# Altium schematic sheet LOGIC_FPGA.SchDoc
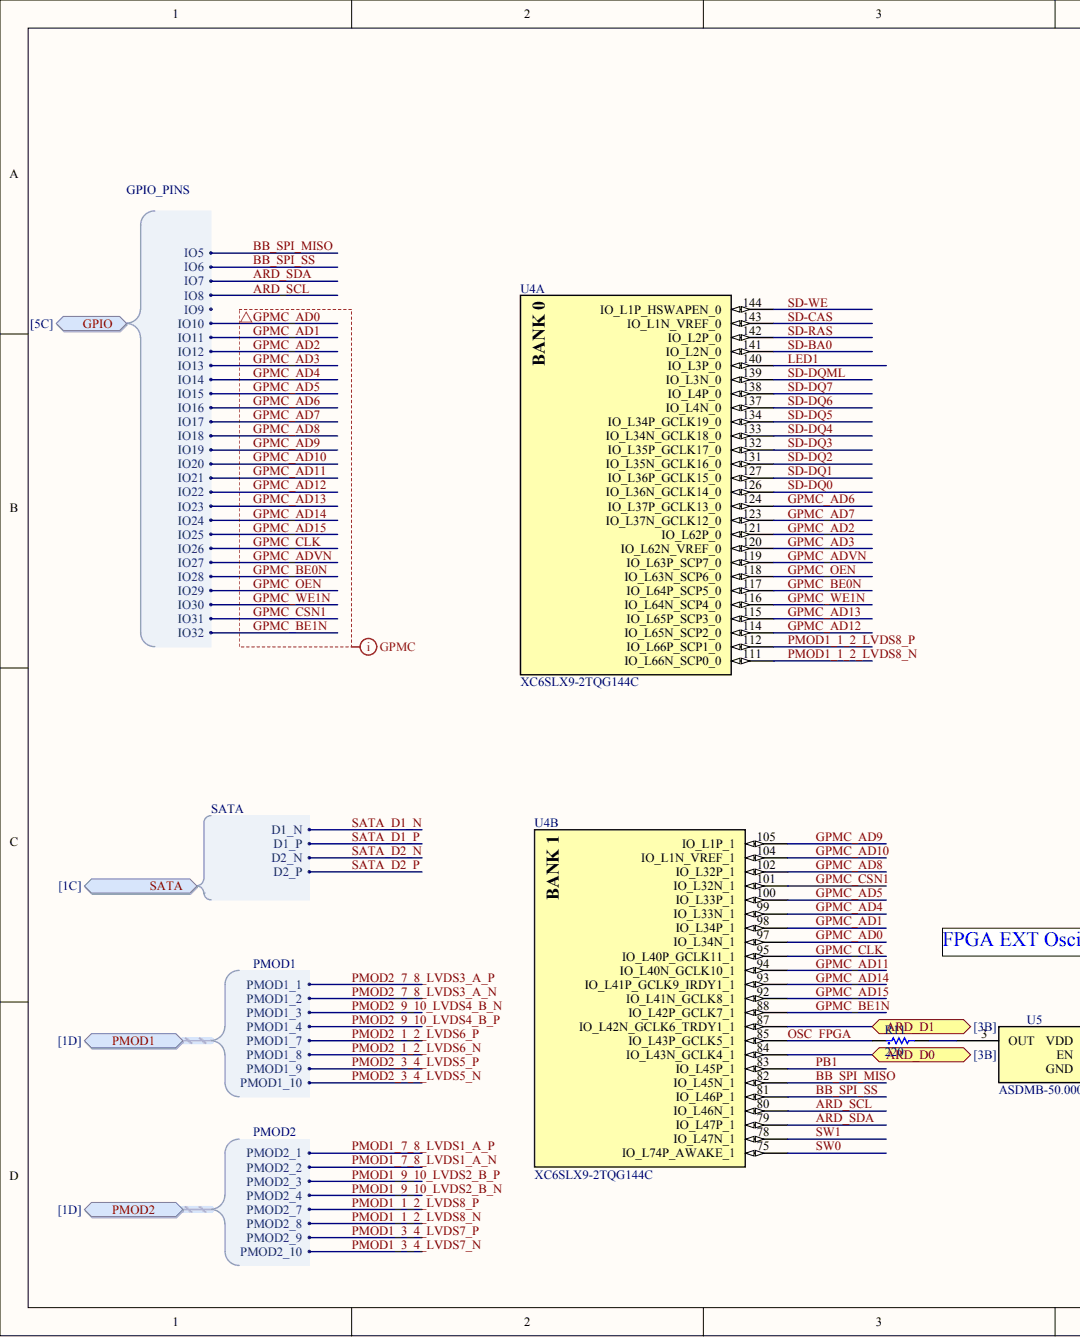
[diagram p0: LOGIC_FPGA.SchDoc, left]
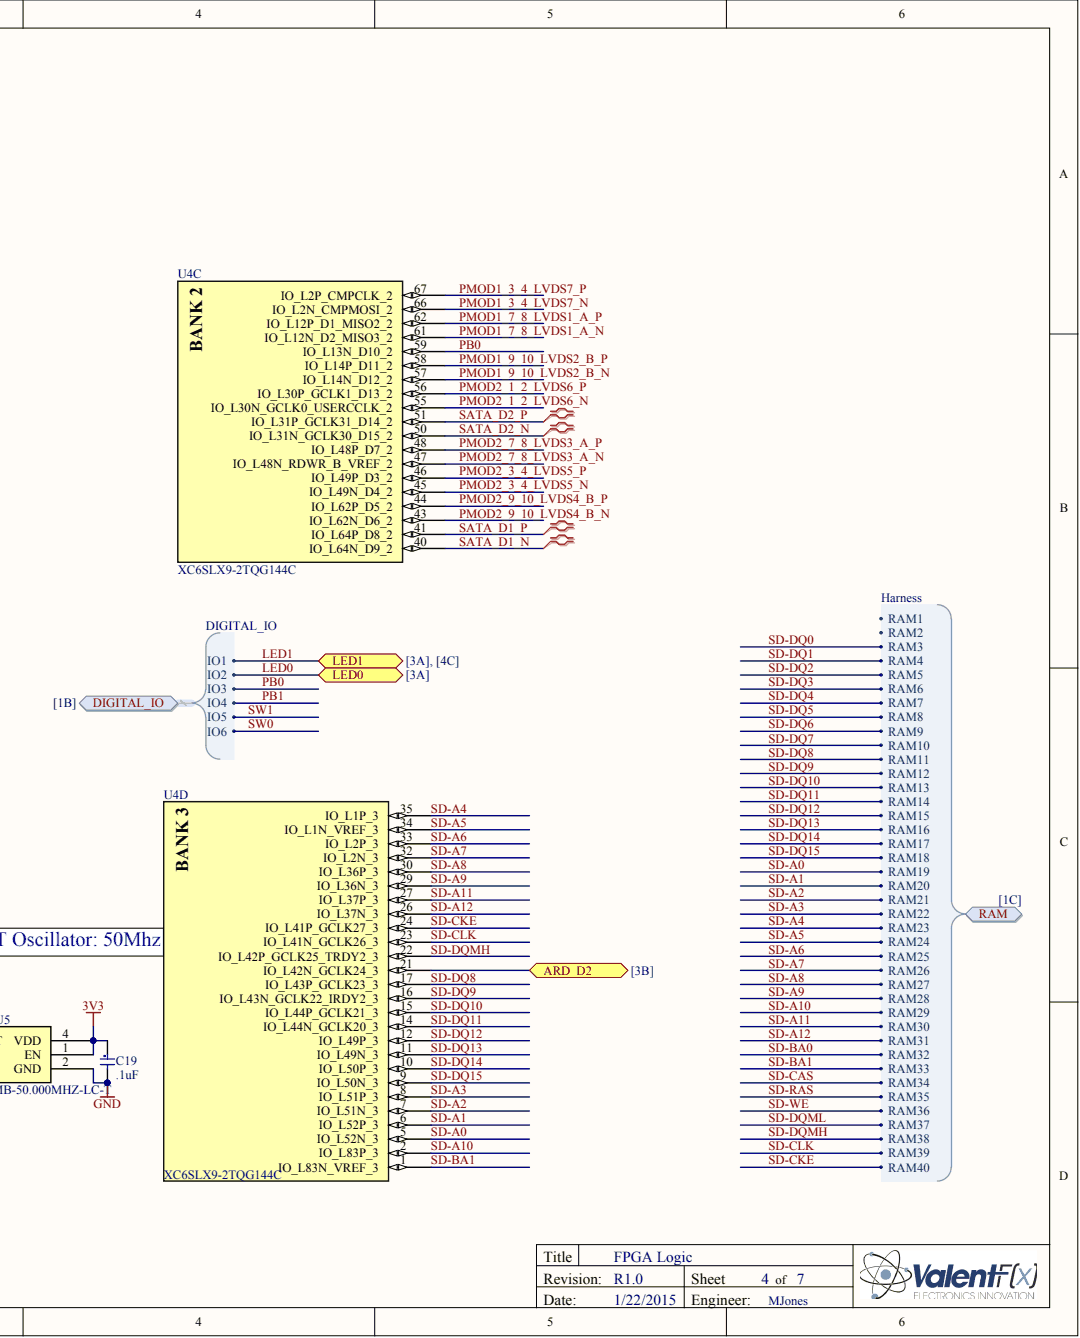
[diagram p1: LOGIC_FPGA.SchDoc, right]

## Parts
C19  .1uF  [c0603]
R11  220  [R0603]
U4  XC6SLX9-2TQG144C  [TQ144_L]  (parts A,B,C,D)
U5  ASDMB-50.000MHZ-LC-T  [ASDMB - osc]

## Ports
ARD_D0, ARD_D1, ARD_D2, DIGITAL_IO, DIGITAL_IO.IO1, DIGITAL_IO.IO2, DIGITAL_IO.IO3, DIGITAL_IO.IO4, DIGITAL_IO.IO5, DIGITAL_IO.IO6, GPIO, GPIO.IO5, GPIO.IO6, GPIO.IO7, GPIO.IO8, GPIO.IO9, GPIO.IO10, GPIO.IO11, GPIO.IO12, GPIO.IO13, GPIO.IO14, GPIO.IO15, GPIO.IO16, GPIO.IO17, GPIO.IO18, GPIO.IO19, GPIO.IO20, GPIO.IO21, GPIO.IO22, GPIO.IO23, GPIO.IO24, GPIO.IO25, GPIO.IO26, GPIO.IO27, GPIO.IO28, GPIO.IO29, GPIO.IO30, GPIO.IO31, GPIO.IO32, LED0, LED1, PMOD1, PMOD1.PMOD1_1, PMOD1.PMOD1_2, PMOD1.PMOD1_3, PMOD1.PMOD1_4, PMOD1.PMOD1_7, PMOD1.PMOD1_8, PMOD1.PMOD1_9, PMOD1.PMOD1_10, PMOD2, PMOD2.PMOD2_1, PMOD2.PMOD2_2, PMOD2.PMOD2_3, PMOD2.PMOD2_4, PMOD2.PMOD2_7, PMOD2.PMOD2_8, PMOD2.PMOD2_9, PMOD2.PMOD2_10, RAM, RAM.RAM1, RAM.RAM2, RAM.RAM3, RAM.RAM4, RAM.RAM5, RAM.RAM6, RAM.RAM7, RAM.RAM8, RAM.RAM9, RAM.RAM10, RAM.RAM11, RAM.RAM12, RAM.RAM13, RAM.RAM14, RAM.RAM15, RAM.RAM16, RAM.RAM17, RAM.RAM18, RAM.RAM19, RAM.RAM20, RAM.RAM21, RAM.RAM22, RAM.RAM23, RAM.RAM24, RAM.RAM25, RAM.RAM26, RAM.RAM27, RAM.RAM28, RAM.RAM29, RAM.RAM30, RAM.RAM31, RAM.RAM32, RAM.RAM33, RAM.RAM34, RAM.RAM35, RAM.RAM36, RAM.RAM37, RAM.RAM38, RAM.RAM39, RAM.RAM40, SATA, SATA.D1_N, SATA.D1_P, SATA.D2_N, SATA.D2_P

## Nets
3V3: C19.1 U5.1(EN) U5.4(VDD)
GND: C19.2 U5.2(GND)
ARD_D0: U4.84(IO_L43N_GCLK4_1) ; port ARD_D0
ARD_D1: U4.87(IO_L42N_GCLK6_TRDY1_1) ; port ARD_D1
ARD_D2: U4.21(IO_L42N_GCLK24_3) ; port ARD_D2
ARD_SCL: U4.80(IO_L46N_1) ; port GPIO
ARD_SDA: U4.79(IO_L47P_1) ; port GPIO
BB_SPI_MISO: U4.82(IO_L45N_1) ; port GPIO
BB_SPI_SS: U4.81(IO_L46P_1) ; port GPIO
GPMC_AD0: U4.97(IO_L34N_1) ; port GPIO
GPMC_AD1: U4.98(IO_L34P_1) ; port GPIO
GPMC_AD2: U4.121(IO_L62P_0) ; port GPIO
GPMC_AD3: U4.120(IO_L62N_VREF_0) ; port GPIO
GPMC_AD4: U4.99(IO_L33N_1) ; port GPIO
GPMC_AD5: U4.100(IO_L33P_1) ; port GPIO
GPMC_AD6: U4.124(IO_L37P_GCLK13_0) ; port GPIO
GPMC_AD7: U4.123(IO_L37N_GCLK12_0) ; port GPIO
GPMC_AD8: U4.102(IO_L32P_1) ; port GPIO
GPMC_AD9: U4.105(IO_L1P_1) ; port GPIO
GPMC_AD10: U4.104(IO_L1N_VREF_1) ; port GPIO
GPMC_AD11: U4.94(IO_L40N_GCLK10_1) ; port GPIO
GPMC_AD12: U4.114(IO_L65N_SCP2_0) ; port GPIO
GPMC_AD13: U4.115(IO_L65P_SCP3_0) ; port GPIO
GPMC_AD14: U4.93(IO_L41P_GCLK9_IRDY1_1) ; port GPIO
GPMC_AD15: U4.92(IO_L41N_GCLK8_1) ; port GPIO
GPMC_ADVN: U4.119(IO_L63P_SCP7_0) ; port GPIO
GPMC_BE0N: U4.117(IO_L64P_SCP5_0) ; port GPIO
GPMC_BE1N: U4.88(IO_L42P_GCLK7_1) ; port GPIO
GPMC_CLK: U4.95(IO_L40P_GCLK11_1) ; port GPIO
GPMC_CSN1: U4.101(IO_L32N_1) ; port GPIO
GPMC_OEN: U4.118(IO_L63N_SCP6_0) ; port GPIO
GPMC_WE1N: U4.116(IO_L64N_SCP4_0) ; port GPIO
LED1: U4.140(IO_L3P_0) ; port DIGITAL_IO, port LED1
OSC_FPGA: R11.1 U4.85(IO_L43P_GCLK5_1)
PB0: U4.59(IO_L13N_D10_2) ; port DIGITAL_IO
PB1: U4.83(IO_L45P_1) ; port DIGITAL_IO
PMOD1_1_2_LVDS8_N: U4.111(IO_L66N_SCP0_0) ; port PMOD2
PMOD1_1_2_LVDS8_P: U4.112(IO_L66P_SCP1_0) ; port PMOD2
PMOD1_3_4_LVDS7_N: U4.66(IO_L2N_CMPMOSI_2) ; port PMOD2
PMOD1_3_4_LVDS7_P: U4.67(IO_L2P_CMPCLK_2) ; port PMOD2
PMOD1_7_8_LVDS1_A_N: U4.61(IO_L12N_D2_MISO3_2) ; port PMOD2
PMOD1_7_8_LVDS1_A_P: U4.62(IO_L12P_D1_MISO2_2) ; port PMOD2
PMOD1_9_10_LVDS2_B_N: U4.57(IO_L14N_D12_2) ; port PMOD2
PMOD1_9_10_LVDS2_B_P: U4.58(IO_L14P_D11_2) ; port PMOD2
PMOD2_1_2_LVDS6_N: U4.55(IO_L30N_GCLK0_USERCCLK_2) ; port PMOD1
PMOD2_1_2_LVDS6_P: U4.56(IO_L30P_GCLK1_D13_2) ; port PMOD1
PMOD2_3_4_LVDS5_N: U4.45(IO_L49N_D4_2) ; port PMOD1
PMOD2_3_4_LVDS5_P: U4.46(IO_L49P_D3_2) ; port PMOD1
PMOD2_7_8_LVDS3_A_N: U4.47(IO_L48N_RDWR_B_VREF_2) ; port PMOD1
PMOD2_7_8_LVDS3_A_P: U4.48(IO_L48P_D7_2) ; port PMOD1
PMOD2_9_10_LVDS4_B_N: U4.43(IO_L62N_D6_2) ; port PMOD1
PMOD2_9_10_LVDS4_B_P: U4.44(IO_L62P_D5_2) ; port PMOD1
SATA_D1_N: U4.40(IO_L64N_D9_2) ; port SATA
SATA_D1_P: U4.41(IO_L64P_D8_2) ; port SATA
SATA_D2_N: U4.50(IO_L31N_GCLK30_D15_2) ; port SATA
SATA_D2_P: U4.51(IO_L31P_GCLK31_D14_2) ; port SATA
SD-A0: U4.5(IO_L52N_3) ; port RAM
SD-A1: U4.6(IO_L52P_3) ; port RAM
SD-A2: U4.7(IO_L51N_3) ; port RAM
SD-A3: U4.8(IO_L51P_3) ; port RAM
SD-A4: U4.35(IO_L1P_3) ; port RAM
SD-A5: U4.34(IO_L1N_VREF_3) ; port RAM
SD-A6: U4.33(IO_L2P_3) ; port RAM
SD-A7: U4.32(IO_L2N_3) ; port RAM
SD-A8: U4.30(IO_L36P_3) ; port RAM
SD-A9: U4.29(IO_L36N_3) ; port RAM
SD-A10: U4.2(IO_L83P_3) ; port RAM
SD-A11: U4.27(IO_L37P_3) ; port RAM
SD-A12: U4.26(IO_L37N_3) ; port RAM
SD-BA0: U4.141(IO_L2N_0) ; port RAM
SD-BA1: U4.1(IO_L83N_VREF_3) ; port RAM
SD-CAS: U4.143(IO_L1N_VREF_0) ; port RAM
SD-CKE: U4.24(IO_L41P_GCLK27_3) ; port RAM
SD-CLK: U4.23(IO_L41N_GCLK26_3) ; port RAM
SD-DQ0: U4.126(IO_L36N_GCLK14_0) ; port RAM
SD-DQ1: U4.127(IO_L36P_GCLK15_0) ; port RAM
SD-DQ2: U4.131(IO_L35N_GCLK16_0) ; port RAM
SD-DQ3: U4.132(IO_L35P_GCLK17_0) ; port RAM
SD-DQ4: U4.133(IO_L34N_GCLK18_0) ; port RAM
SD-DQ5: U4.134(IO_L34P_GCLK19_0) ; port RAM
SD-DQ6: U4.137(IO_L4N_0) ; port RAM
SD-DQ7: U4.138(IO_L4P_0) ; port RAM
SD-DQ8: U4.17(IO_L43P_GCLK23_3) ; port RAM
SD-DQ9: U4.16(IO_L43N_GCLK22_IRDY2_3) ; port RAM
SD-DQ10: U4.15(IO_L44P_GCLK21_3) ; port RAM
SD-DQ11: U4.14(IO_L44N_GCLK20_3) ; port RAM
SD-DQ12: U4.12(IO_L49P_3) ; port RAM
SD-DQ13: U4.11(IO_L49N_3) ; port RAM
SD-DQ14: U4.10(IO_L50P_3) ; port RAM
SD-DQ15: U4.9(IO_L50N_3) ; port RAM
SD-DQMH: U4.22(IO_L42P_GCLK25_TRDY2_3) ; port RAM
SD-DQML: U4.139(IO_L3N_0) ; port RAM
SD-RAS: U4.142(IO_L2P_0) ; port RAM
SD-WE: U4.144(IO_L1P_HSWAPEN_0) ; port RAM
SW0: U4.75(IO_L74P_AWAKE_1) ; port DIGITAL_IO
SW1: U4.78(IO_L47N_1) ; port DIGITAL_IO
NetR11_2: R11.2 U5.3(OUT)
LED0: ; port DIGITAL_IO, port LED0
N00045: ; port RAM
N00046: ; port RAM
N00047:
N00048: ; port GPIO

## Library data of the parts
C19 = C0603 - CL10F104ZA8NNNC: CAP CER 0.1UF 25V Y5V 0603; Manufacturer: Samsung Electro-Mechanics America, Inc; Accept Alternative: Yes; Capacitance: 0.1µF; Temperature Coefficient: Y5V (F); Tolerance: -20%, +80%; Accept Compatible Replacement Part: Yes; Applications: General Purpose; Category: Capacitors; Component Kind: Standard; ComponentLink1Description: http://www.samsungsem.com/servlet/FileDownload?type=spec&file=CL10F104ZA8NNNC.pdf; ComponentLink1URL: http://www.samsungsem.com/servlet/FileDownload?type=spec&file=CL10F104ZA8NNNC.pdf; Features: -; Height - Seated (Max): -; Lead Spacing: -; Lead Style: -; Library Name: mCapacitors.SchLib; Manufacturer Part Number: CL10F104ZA8NNNC; Mounting Type: Surface Mount, MLCC; Operating Temperature: -30°C ~ 85°C; Package / Case: 0603 (1608 Metric); Packaging: Cut Tape (CT); Pricing 1: 1=0.1, 10=0.017, 25=0.012, 50=0.009, 100=0.0076, 250=0.00624, 500=0.00536, 1000=0.00417 (USD); Ratings: -; RoHS: RoHS Compliant; Size / Dimension: 0.063" L x 0.031" W (1.60mm x 0.80mm); Stock 1: 400907; Supplier 1: Digi-Key; Supplier Part Number 1: 1276-1011-1-ND; Thickness (Max): 0.035" (0.90mm); Value: .1uF; Voltage - Rated: 25V
R11 = R0603 - ERJ-3EKF2200V: 220 - thick Film Resistors - SMD 0603 220ohms 1% Tol; Accept Compatible Replacement Part: Yes; Manufacturer: Panasonic - ECG; Power (Watts): 0.1W, 1/10W; Temperature Coefficient: ±100ppm/°C; Category: Resistors; Component Kind: Standard; ComponentLink1Description: http://industrial.panasonic.com/www-cgi/jvcr13pz.cgi?E+PZ+3+AOA0002+ERJ3EKF2200V+7+WW; ComponentLink1URL: http://industrial.panasonic.com/www-cgi/jvcr13pz.cgi?E+PZ+3+AOA0002+ERJ3EKF2200V+7+WW; Composition: Thick Film; Features: -; Height: 0.022" (0.55mm); Library Name: mResistors.SchLib; Manufacturer Part Number: ERJ-3EKF2200V; Number of Terminations: 2; Package / Case: 0603 (1608 Metric); Packaging: Cut Tape (CT); Pricing 1: 1=0.1, 50=0.0138, 100=0.0105, 250=0.0078, 500=0.00576, 1000=0.00384 (USD); Resistance (Ohms): 220; RoHS: RoHS Compliant; Size / Dimension: 0.063" L x 0.031" W (1.60mm x 0.80mm); Stock 1: 517798; Supplier 1: Digi-Key; Supplier Part Number 1: P220HCT-ND; Tolerance: ±1%; Value: 220
U4 = FPGA - XC6SLX9-2TQG144C: IC FPAG SPARTAN 6 9K 144TQFP; Manufacturer: Xilinx Inc; Number of I /O: 102; Number of LABs/CLBs: 715; Number of Logic Elements/Cells: 9152; Total RAM Bits: 589824; Voltage - Supply: 1.14 V ~ 1.26 V; Category: Integrated Circuits (ICs); Component Kind: Standard; ComponentLink1Description: http://www.xilinx.com/support/documentation/data_sheets/ds160.pdf; ComponentLink1URL: http://www.xilinx.com/support/documentation/data_sheets/ds160.pdf; Library Name: mIC.SchLib; Manufacturer Part Number: XC6SLX9-2TQG144C; Mounting Type: Surface Mount; Number of Gates: -; Operating Temperature: 0°C ~ 85°C; Package / Case: 144-LQFP; Pricing 1: 1=12.80,1=11.05,1000=9.59 (USD); RoHS: RoHS Compliant; Stock 1: 994; Supplier 1: America II Electronics; Supplier 2: Digi-Key; Supplier Device Package: 144-TQFP (20x20); Supplier Part Number 1: 122-1745-ND; Value: XC6SLX9-2TQG144C
U5 = ASDMB-50.000MHZ-LC-T: OSC MEMS 50.000 MHZ SMD; Manufacturer: Abracon Corporation; Current - Supply (Disable) (Max): 15µA; Current - Supply (Max): 16mA; Frequency: 50MHz; Frequency Stability: ±50ppm; Function: Tri-State (Output Enable); Output: CMOS; Voltage - Supply: 1.8 V ~ 3.3 V; Category: Crystals and Oscillators; Component Kind: Standard; ComponentLink1Description: http://www.abracon.com/Oscillators/ASDMB.pdf; ComponentLink1URL: http://www.abracon.com/Oscillators/ASDMB.pdf; Height: 0.035" (0.90mm); Library Name: eIC.SchLib; Manufacturer Part Number: ASDMB-50.000MHZ-LC-T; Mounting Type: Surface Mount; Operating Temperature: -40°C ~ 85°C; Package / Case: 4-SMD, No Lead (DFN, LCC); Packaging: Cut Tape (CT); Pricing 1: 1=1.69, 10=1.463, 50=1.2826, 100=1.0125, 500=0.855 (USD); RoHS: RoHS Compliant; Size / Dimension: 0.098" L x 0.079" W (2.50mm x 2.00mm); Stock 1: 967; Supplier 1: Digi-Key; Supplier Part Number 1: 535-11738-1-ND; Type: MEMS (Silicon); Value: ASDMB-50.000MHZ-LC-T
